# Altium schematic sheet Voltage_Regulation_TI.SchDoc(Voltage_Regulation_TI)
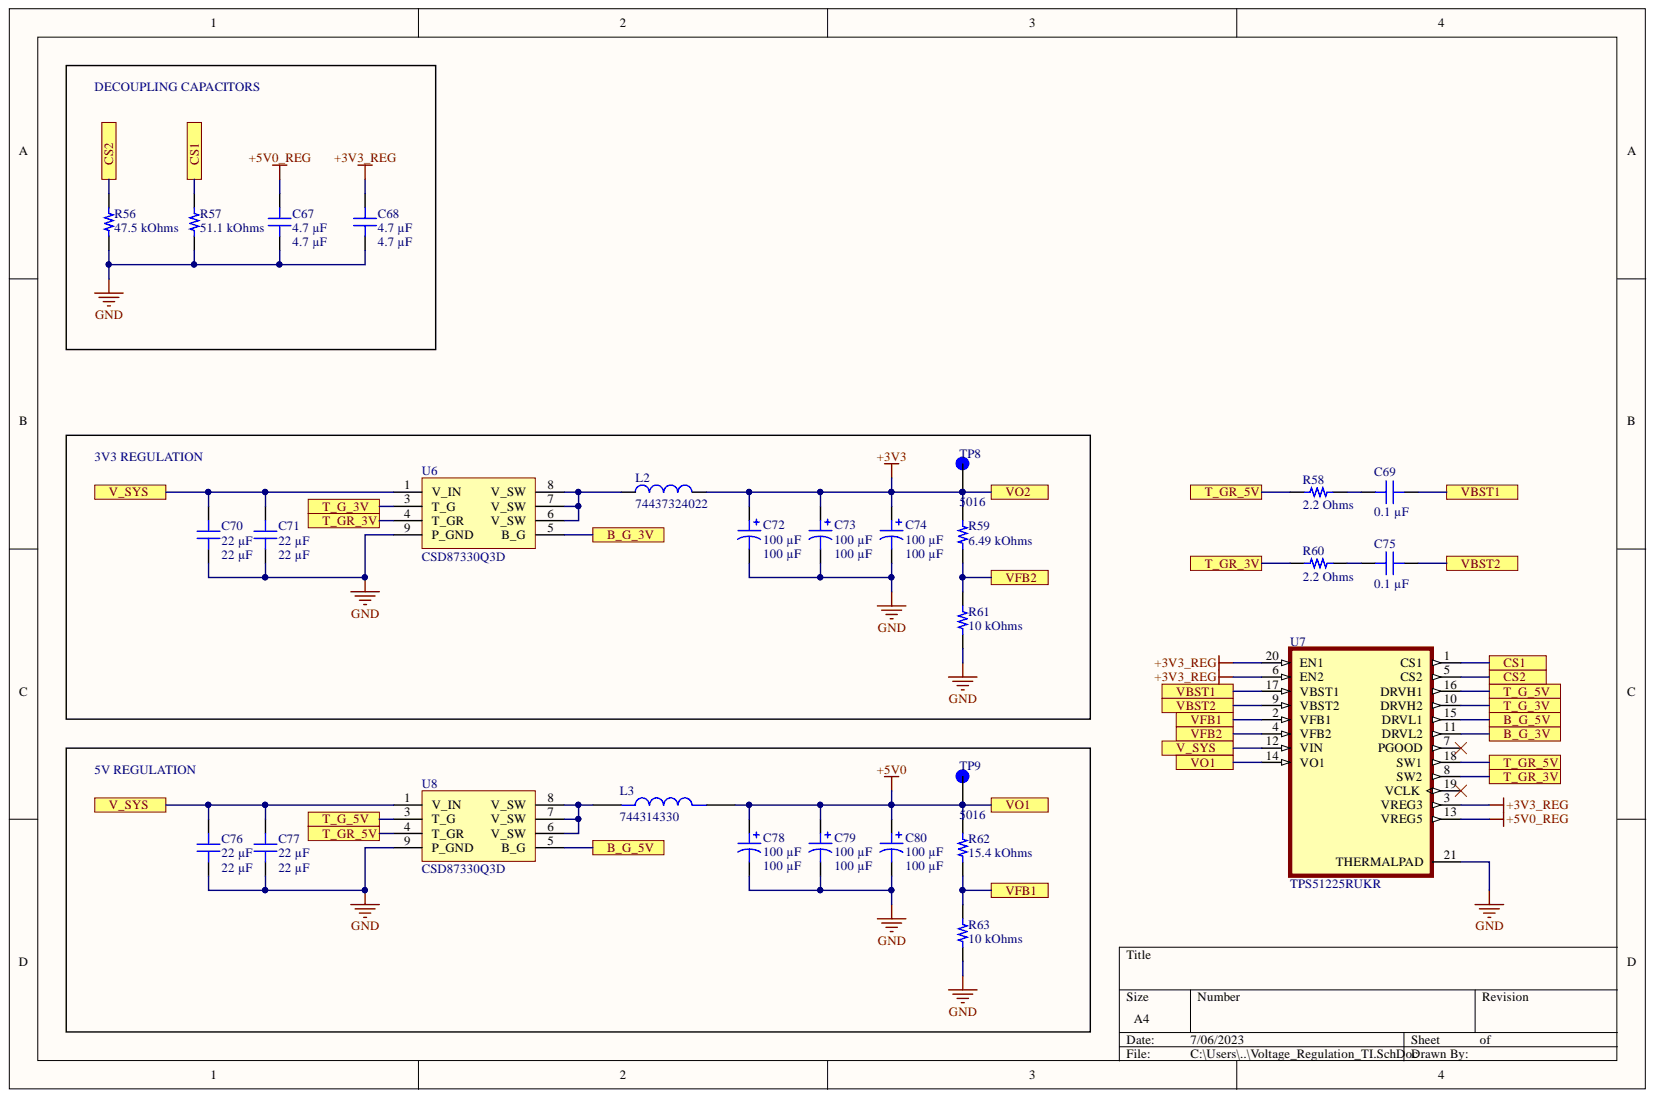
[diagram p0: Voltage_Regulation_TI.SchDoc]

## Parts
C67  4.7 µF  [CAP 0402_1005]
C68  4.7 µF  [CAP 0402_1005]
C69  0.1 µF  [CAP 0402_1005]
C70  22 µF  [CAP 0805_2012]
C71  22 µF  [CAP 0805_2012]
C72  100 µF  [CAP POL 1411_3528]
C73  100 µF  [CAP POL 1411_3528]
C74  100 µF  [CAP POL 1411_3528]
C75  0.1 µF  [CAP 0402_1005]
C76  22 µF  [CAP 0805_2012]
C77  22 µF  [CAP 0805_2012]
C78  100 µF  [CAP POL 1411_3528]
C79  100 µF  [CAP POL 1411_3528]
C80  100 µF  [CAP POL 1411_3528]
L2  74437324022  [IND_74437324022]
L3  744314330  [IND_744314330]
R56  47.5 kOhms  [RES 0402_1005]
R57  51.1 kOhms  [RES 0402_1005]
R58  2.2 Ohms  [RES 0402_1005]
R59  6.49 kOhms  [RES 0402_1005]
R60  2.2 Ohms  [RES 0402_1005]
R61  10 kOhms  [RES 0402_1005]
R62  15.4 kOhms  [RES 0402_1005]
R63  10 kOhms  [RES 0402_1005]
TP8  5016  [KEYSTONE_5016]
TP9  5016  [KEYSTONE_5016]
U6  CSD87330Q3D  [Q3D]
U7  TPS51225RUKR  [QFN40P300X300X80-21N]
U8  CSD87330Q3D  [Q3D]

## Ports
B_G_3V, B_G_5V, CS1, CS2, T_G_3V, T_G_5V, T_GR_3V, T_GR_5V, V_SYS, VBST1, VBST2, VFB1, VFB2, VO1, VO2

## Nets
+3V3_REG: C68.2 U7.3(VREG3) U7.6(EN2) U7.20(EN1)
+5V0_REG: C67.2 U7.13(VREG5)
GND: C67.1 C68.1 C70.1 C71.1 C72.2 C73.2 C74.2 C76.1 C77.1 C78.2 C79.2 C80.2 R56.1 R57.1 R61.2 R63.2 U6.9(P_GND) U7.21(THERMALPAD) U8.9(P_GND)
+3V3: C72.1 C73.1 C74.1 L2.2 R59.2 TP8.TP ; port VO2
+5V0: C78.1 C79.1 C80.1 L3.2 R62.2 TP9.TP U7.14(VO1) ; port VO1
B_G_3V: U6.5(B_G) U7.11(DRVL2) ; port B_G_3V
B_G_5V: U7.15(DRVL1) U8.5(B_G) ; port B_G_5V
CS1: R57.2 U7.1(CS1) ; port CS1
CS2: R56.2 U7.5(CS2) ; port CS2
T_G_3V: U6.3(T_G) U7.10(DRVH2) ; port T_G_3V
T_G_5V: U7.16(DRVH1) U8.3(T_G) ; port T_G_5V
T_GR_3V: R60.1 U6.4(T_GR) U7.8(SW2) ; port T_GR_3V
T_GR_5V: R58.1 U7.18(SW1) U8.4(T_GR) ; port T_GR_5V
V_SYS: C70.2 C71.2 C76.2 C77.2 U6.1(V_IN) U7.12(VIN) U8.1(V_IN) ; port V_SYS
VBST1: C69.1 U7.17(VBST1) ; port VBST1
VBST2: C75.1 U7.9(VBST2) ; port VBST2
VFB1: R62.1 R63.1 U7.2(VFB1) ; port VFB1
VFB2: R59.1 R61.1 U7.4(VFB2) ; port VFB2
NetC69_2: C69.2 R58.2
NetC75_2: C75.2 R60.2
NetL2_1: L2.1 U6.6(V_SW) U6.7(V_SW) U6.8(V_SW)
NetL3_1: L3.1 U8.6(V_SW) U8.7(V_SW) U8.8(V_SW)

Not connected: U7 7(PGOOD) 19(VCLK)

## Library data of the parts
C67 C68 = CL05A475MO5NUNC: CAP CER 4.7UF 16V X5R 0402; manufacturer: Samsung Electro-Mechanics; Tolerance: ±20%; Database Table Name: Capacitors - Ceramic - 0402; Voltage - Rated: 16V; Value: 4.7 µF; Thickness (Max): 0.028" (0.70mm); Temperature Coefficient: X5R; Supplier Part Number 1: 1276-6836-1-ND; Supplier 1: DigiKey; Size / Dimension: 0.039" L x 0.020" W (1.00mm x 0.50mm); series: CL; price: 0.08; Part Status: Active; Part Number: CL05A475MO5NUNC; partid: 1760; packaging: TapeAndReel; Package / Case: 0402 (1005 Metric); Operating Temperature: -55°C ~ 85°C; Mounting Type: Surface Mount, MLCC; Minimum Order: 1; Lifecycle Status: Active; Library Name: Celestial Altium Library - altium_library.DbLib; lastupdated: 27/04/2023 7:05:02 PM; Device Package: 0402 (1005 Metric); componentlink2url: https://www.digikey.com/en/products/detail/samsung-electro-mechanics/CL05A475MO5NUNC/5961320; componentlink2description: DigiKey Link; componentlink1url: http://www.samsungsem.com/kr/support/product-search/mlcc/__icsFiles/afieldfile/2019/05/29/Data%20sheet_CL05A475MO5NUNC.pdf; componentlink1description: Datasheet; Component Kind: Standard; Capacitance: 4.7 µF; Applications: General Purpose
C69 C75 = 885012105001: CAP CER 0.1UF 6.3V X5R 0402; manufacturer: Würth Elektronik; Tolerance: ±20%; Database Table Name: Capacitors - Ceramic - 0402; Voltage - Rated: 6.3V; Value: 0.1 µF; Thickness (Max): 0.022" (0.55mm); Temperature Coefficient: X5R; Supplier Part Number 1: 732-7478-1-ND; Supplier 1: DigiKey; Size / Dimension: 0.039" L x 0.020" W (1.00mm x 0.50mm); series: WCAP-CSGP; price: 0.01; Part Status: Active; Part Number: 885012105001; partid: 1931; packaging: TapeAndReel; Package / Case: 0402 (1005 Metric); Operating Temperature: -55°C ~ 85°C; Mounting Type: Surface Mount, MLCC; Minimum Order: 1; Lifecycle Status: Active; Library Name: Celestial Altium Library - altium_library.DbLib; lastupdated: 26/04/2023 7:02:37 PM; Device Package: 0402 (1005 Metric); componentlink2url: https://www.digikey.com/en/products/detail/würth-elektronik/885012105001/5453333; componentlink2description: DigiKey Link; componentlink1url: https://katalog.we-online.de/pbs/datasheet/885012105001.pdf; componentlink1description: Datasheet; Component Kind: Standard; Capacitance: 0.1 µF; Applications: General Purpose
C70 C71 C76 C77 = C2012X5R1V226M125AC: CAP CER 22UF 35V X5R 0805; manufacturer: TDK Corporation; Tolerance: ±20%; Database Table Name: Capacitors - Ceramic - 0805; Voltage - Rated: 35V; Value: 22 µF; Thickness (Max): 0.057" (1.45mm); Temperature Coefficient: X5R; Supplier Part Number 1: 445-14428-1-ND; Supplier 1: DigiKey; Size / Dimension: 0.079" L x 0.049" W (2.00mm x 1.25mm); series: C; price: 0.28; Part Status: Active; Part Number: C2012X5R1V226M125AC; partid: 45365; packaging: TapeAndReel; Package / Case: 0805 (2012 Metric); Operating Temperature: -55°C ~ 85°C; Mounting Type: Surface Mount, MLCC; Minimum Order: 1; Lifecycle Status: Active; Library Name: Celestial Altium Library - altium_library.DbLib; lastupdated: 27/04/2023 7:13:26 PM; Features: Low ESL; Device Package: 0805 (2012 Metric); componentlink2url: https://www.digikey.com/en/products/detail/tdk-corporation/C2012X5R1V226M125AC/3951664; componentlink2description: DigiKey Link; componentlink1url: https://product.tdk.com/info/en/catalog/datasheets/mlcc_commercial_general_en.pdf?ref_disty=digikey; componentlink1description: Datasheet; Component Kind: Standard; Capacitance: 22 µF; Applications: General Purpose
C72-C74 C78-C80 = ATCC-211B-010-107M-T: CAP TANT 100UF 20% 10V 1411; Manufacturer Size Code: B; manufacturer: Abracon LLC; Type: Molded; ESR (Equivalent Series Resistance): 1.5Ohm; Database Table Name: Capacitors - Tantalum; Voltage - Rated: 10 V; Value: 100 µF; Tolerance: ±20%; Supplier Part Number 1: ATCC-211B-010-107M-TCT-ND; Supplier 1: DigiKey; Size / Dimension: 0.138" L x 0.110" W (3.50mm x 2.80mm); series: ATCC-211; price: 0.45; Part Status: Active; Part Number: ATCC-211B-010-107M-T; partid: 25; packaging: TapeAndReel; Package / Case: 1411 (3528 Metric); Operating Temperature: -55°C ~ 125°C; Mounting Type: Surface Mount; Minimum Order: 1; Lifecycle Status: Active; Library Name: Celestial Altium Library - altium_library.DbLib; lastupdated: 27/04/2023 7:52:12 PM; Height - Seated (Max): 0.083" (2.10mm); Features: General Purpose; Device Package: 1411 (3528 Metric); componentlink2url: https://www.digikey.com/en/products/detail/abracon-llc/ATCC-211B-010-107M-T/4245645; componentlink2description: DigiKey Link; componentlink1url: https://abracon.com/Magnetics/inductors/ATCC-211.pdf; componentlink1description: Datasheet; Component Kind: Standard; Capacitance: 100 µF
L2 = 74437324022: LHMI Inductor 4020 2.2uH 5.0A 27.0mOhm; Value: 2.2µH; MF: {'manufacturer': u'W\xfcrth Elektronik'}; Price: None; Package: None; MP: 74437324022; Component Kind: Standard; Availability: Unavailable
L3 = 744314330: Inductor SMD 7050 3.3µH 9 A Wuerth Elektronik WE-HCI 744314330 1 pc(s); MF: {'manufacturer': u'Wurth Electronics'}; Value: 3.3µH; Price: None; Package: None; MP: 744314330; Component Kind: Standard; Availability: Unavailable
R56 = RC0402FR-0747K5L: RES 47.5K OHM 1% 1/16W 0402; Manufacturer: Yageo; Temperature Coefficient: ±100ppm/°C; Power (Watts): 0.063W, 1/16W; Database Table Name: Resistors - Surface Mount - 0402; Value: 47.5 kOhms; Tolerance: ±1%; Supplier Part Number 1: 311-47.5KLRCT-ND; Supplier Device Package: 0402; Supplier 1: DigiKey; Size / Dimension: 0.039" L x 0.020" W (1.00mm x 0.50mm); Series: RC_L; Resistance: 47.5 kOhms; Price: 0.01; Part Status: Active; Part Number: RC0402FR-0747K5L; PartId: 2538; Packaging: CutTape; Package / Case: 0402 (1005 Metric); Operating Temperature: -55°C ~ 155°C; Number of Terminations: 2; Minimum Order: 1; Lifecycle Status: Active; Library Name: Celestial Altium Library - altium_library.DbLib; LastUpdated: 27/04/2023 7:26:05 PM; Height - Seated (Max): 0.016" (0.40mm); Features: Moisture Resistant; Device Package: 0402 (1005 Metric); Composition: Thick Film; ComponentLink2URL: https://www.digikey.com/en/products/detail/yageo/RC0402FR-0747K5L/726617; ComponentLink2Description: DigiKey Link; ComponentLink1URL: https://www.yageo.com/upload/media/product/productsearch/datasheet/rchip/PYu-RC_Group_51_RoHS_L_10.pdf; ComponentLink1Description: Datasheet; Component Kind: Standard
R57 = CR0402-FX-5112GLF: RES SMD 51.1K OHM 1% 1/16W 0402; Manufacturer: Bourns Inc.; Temperature Coefficient: ±100ppm/°C; Power (Watts): 0.063W, 1/16W; Database Table Name: Resistors - Surface Mount - 0402; Value: 51.1 kOhms; Tolerance: ±1%; Supplier Part Number 1: CR0402-FX-5112GLFCT-ND; Supplier Device Package: 0402; Supplier 1: DigiKey; Size / Dimension: 0.039" L x 0.020" W (1.00mm x 0.50mm); Series: CR0402; Resistance: 51.1 kOhms; Price: 0.01; Part Status: Active; Part Number: CR0402-FX-5112GLF; PartId: 4388; Packaging: CutTape; Package / Case: 0402 (1005 Metric); Operating Temperature: -55°C ~ 155°C; Number of Terminations: 2; Minimum Order: 1; Lifecycle Status: Active; Library Name: Celestial Altium Library - altium_library.DbLib; LastUpdated: 27/04/2023 7:25:58 PM; Height - Seated (Max): 0.016" (0.40mm); Device Package: 0402 (1005 Metric); Composition: Thick Film; ComponentLink2URL: https://www.digikey.com/en/products/detail/bourns-inc/CR0402-FX-5112GLF/3783414; ComponentLink2Description: DigiKey Link; ComponentLink1URL: https://www.bourns.com/docs/Product-Datasheets/CR0402.pdf; ComponentLink1Description: Datasheet; Component Kind: Standard
R58 R60 = RC0402JR-072R2L: RES 2.2 OHM 5% 1/16W 0402; Manufacturer: Yageo; Temperature Coefficient: ±200ppm/°C; Power (Watts): 0.063W, 1/16W; Database Table Name: Resistors - Surface Mount - 0402; Value: 2.2 Ohms; Tolerance: ±5%; Supplier Part Number 1: 311-2.2JRCT-ND; Supplier Device Package: 0402; Supplier 1: DigiKey; Size / Dimension: 0.039" L x 0.020" W (1.00mm x 0.50mm); Series: RC_L; Resistance: 2.2 Ohms; Price: 0.01; Part Status: Active; Part Number: RC0402JR-072R2L; PartId: 3413; Packaging: CutTape; Package / Case: 0402 (1005 Metric); Operating Temperature: -55°C ~ 155°C; Number of Terminations: 2; Minimum Order: 1; Lifecycle Status: Active; Library Name: Celestial Altium Library - altium_library.DbLib; LastUpdated: 27/04/2023 7:26:02 PM; Height - Seated (Max): 0.016" (0.40mm); Features: Moisture Resistant; Device Package: 0402 (1005 Metric); Composition: Thick Film; ComponentLink2URL: https://www.digikey.com/en/products/detail/yageo/RC0402JR-072R2L/726435; ComponentLink2Description: DigiKey Link; ComponentLink1URL: https://www.yageo.com/upload/media/product/productsearch/datasheet/rchip/PYu-RC_Group_51_RoHS_L_10.pdf; ComponentLink1Description: Datasheet; Component Kind: Standard
R59 = RC0402FR-076K49L: RES 6.49K OHM 1% 1/16W 0402; Manufacturer: Yageo; Temperature Coefficient: ±100ppm/°C; Power (Watts): 0.063W, 1/16W; Database Table Name: Resistors - Surface Mount - 0402; Value: 6.49 kOhms; Tolerance: ±1%; Supplier Part Number 1: 311-6.49KLRCT-ND; Supplier Device Package: 0402; Supplier 1: DigiKey; Size / Dimension: 0.039" L x 0.020" W (1.00mm x 0.50mm); Series: RC_L; Resistance: 6.49 kOhms; Price: 0.01; Part Status: Active; Part Number: RC0402FR-076K49L; PartId: 2571; Packaging: CutTape; Package / Case: 0402 (1005 Metric); Operating Temperature: -55°C ~ 155°C; Number of Terminations: 2; Minimum Order: 1; Lifecycle Status: Active; Library Name: Celestial Altium Library - altium_library.DbLib; LastUpdated: 27/04/2023 7:26:05 PM; Height - Seated (Max): 0.016" (0.40mm); Features: Moisture Resistant; Device Package: 0402 (1005 Metric); Composition: Thick Film; ComponentLink2URL: https://www.digikey.com/en/products/detail/yageo/RC0402FR-076K49L/726641; ComponentLink2Description: DigiKey Link; ComponentLink1URL: https://www.yageo.com/upload/media/product/productsearch/datasheet/rchip/PYu-RC_Group_51_RoHS_L_10.pdf; ComponentLink1Description: Datasheet; Component Kind: Standard
R61 R63 = RC0402JR-1310KL: RES 10K OHM 5% 1/16W 0402; Manufacturer: Yageo; Temperature Coefficient: ±100ppm/°C; Power (Watts): 0.063W, 1/16W; Database Table Name: Resistors - Surface Mount - 0402; Value: 10 kOhms; Tolerance: ±5%; Supplier Part Number 1: 13-RC0402JR-1310KLTR-ND; Supplier Device Package: 0402; Supplier 1: DigiKey; Size / Dimension: 0.039" L x 0.020" W (1.00mm x 0.50mm); Series: RC_L; Resistance: 10 kOhms; Price: 0; Part Status: Active; Part Number: RC0402JR-1310KL; PartId: 69237; Package / Case: 0402 (1005 Metric); Operating Temperature: -55°C ~ 155°C; Number of Terminations: 2; Minimum Order: 50000; Lifecycle Status: Active; Library Name: Celestial Altium Library - altium_library.DbLib; LastUpdated: 4/04/2023 7:21:31 PM; Height - Seated (Max): 0.016" (0.40mm); Features: Moisture Resistant; Device Package: 0402 (1005 Metric); Composition: Thick Film; ComponentLink2URL: https://www.digikey.com/en/products/detail/yageo/RC0402JR-1310KL/13694115; ComponentLink2Description: DigiKey Link; ComponentLink1URL: https://www.yageo.com/upload/media/product/productsearch/datasheet/rchip/PYu-RC_Group_51_RoHS_L_11.pdf; ComponentLink1Description: Datasheet; Component Kind: Standard
R62 = RC0402FR-0715K4L: RES 15.4K OHM 1% 1/16W 0402; Manufacturer: Yageo; Temperature Coefficient: ±100ppm/°C; Power (Watts): 0.063W, 1/16W; Database Table Name: Resistors - Surface Mount - 0402; Value: 15.4 kOhms; Tolerance: ±1%; Supplier Part Number 1: YAG2992CT-ND; Supplier Device Package: 0402; Supplier 1: DigiKey; Size / Dimension: 0.039" L x 0.020" W (1.00mm x 0.50mm); Series: RC_L; Resistance: 15.4 kOhms; Price: 0.01; Part Status: Active; Part Number: RC0402FR-0715K4L; PartId: 2575; Packaging: CutTape; Package / Case: 0402 (1005 Metric); Operating Temperature: -55°C ~ 155°C; Number of Terminations: 2; Minimum Order: 1; Lifecycle Status: Active; Library Name: Celestial Altium Library - altium_library.DbLib; LastUpdated: 27/04/2023 7:26:05 PM; Height - Seated (Max): 0.016" (0.40mm); Features: Moisture Resistant; Device Package: 0402 (1005 Metric); Composition: Thick Film; ComponentLink2URL: https://www.digikey.com/en/products/detail/yageo/RC0402FR-0715K4L/5280852; ComponentLink2Description: DigiKey Link; ComponentLink1URL: https://www.yageo.com/upload/media/product/productsearch/datasheet/rchip/PYu-RC_Group_51_RoHS_L_10.pdf; ComponentLink1Description: Datasheet; Component Kind: Standard
TP8 TP9 = 5016: MF: {'manufacturer': u'Keystone Electronics'}; Price: None; Package: None; MP: 5016; Component Kind: Standard; Availability: Unavailable
U6 U8 = CSD87330Q3D: Synchronous Buck NexFET™ Power Block; Library Name: Schlib2.SchLib; Component Kind: Standard
U7 = TPS51225RUKR: MF: {'manufacturer': u'Texas Instruments'}; Price: None; Package: None; MP: TPS51225RUKR; Component Kind: Standard; Availability: Unavailable
